# Altium schematic sheet PowerMotor.SchDoc(PowerMotor)
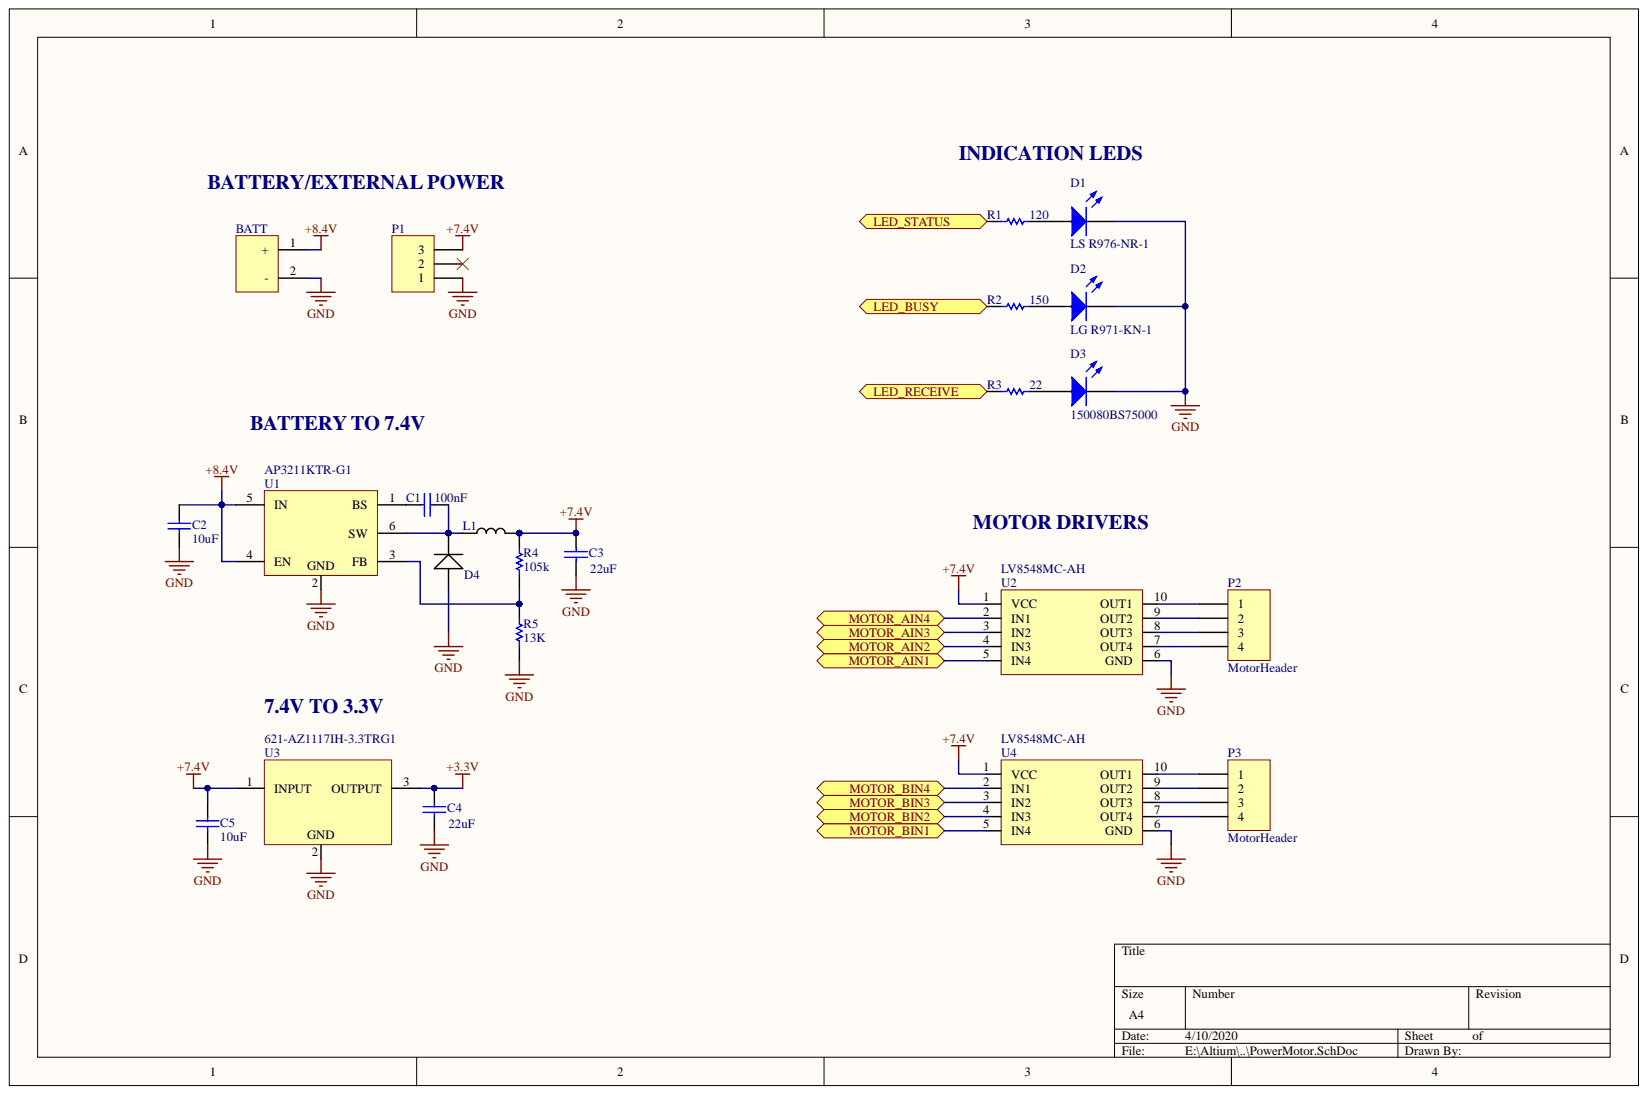
[diagram p0: PowerMotor.SchDoc]

## Parts
BATT  Holes  [BATTMOUNT]  (value not drawn)
C1  100nF  [C1206]
C2  10uF  [C1206]
C3  22uF  [C1206]
C4  22uF  [C1206]
C5  10uF  [C1206]
D1  LS R976-NR-1  [SMD LED 0805]
D2  LG R971-KN-1  [SMD LED 0805]
D3  150080BS75000  [SMD LED 0805]
D4  RSX205LAM30TR  [RSX205LAM30TR]  (value not drawn)
L1  744325550  [744325550]  (value not drawn)
P1  Header 3  [HDR1X3]  (value not drawn)
P2  MotorHeader  [HDR1X4]
P3  MotorHeader  [HDR1X4]
R1  120  [6-0805_N]
R2  150  [6-0805_N]
R3  22  [6-0805_N]
R4  105k  [6-0805_N]
R5  13K  [6-0805_N]
U1  AP3211KTR-G1  [AP3211KTR-G1]
U2  LV8548MC-AH  [LV8548MC-AH]
U3  AZ1117IH-3.3TRG1  Supplier Part Number 1: 621-AZ1117IH-3.3TRG1  [AZ1117IH-5.0TRG1]
U4  LV8548MC-AH  [LV8548MC-AH]

## Ports
LED_BUSY, LED_RECEIVE, LED_STATUS, MOTOR_AIN1, MOTOR_AIN2, MOTOR_AIN3, MOTOR_AIN4, MOTOR_BIN1, MOTOR_BIN2, MOTOR_BIN3, MOTOR_BIN4

## Nets
+3.3V: C4.2 U3.3
+7.4V: C3.2 C5.1 L1.2 P1.3 R4.2 U2.1(VCC) U3.1 U4.1(VCC)
+8.4V: BATT.1 C2.1 U1.4(EN) U1.5(IN)
GND: BATT.2 C2.2 C3.1 C4.1 C5.2 D1.2 D2.2 D3.2 D4.2 P1.1 R5.1 U1.2 U2.6(GND) U3.2 U4.6(GND)
LED_BUSY: R2.2 ; port LED_BUSY
LED_RECEIVE: R3.2 ; port LED_RECEIVE
LED_STATUS: R1.2 ; port LED_STATUS
MOTOR_AIN1: U2.5(IN4) ; port MOTOR_AIN1
MOTOR_AIN2: U2.4(IN3) ; port MOTOR_AIN2
MOTOR_AIN3: U2.3(IN2) ; port MOTOR_AIN3
MOTOR_AIN4: U2.2(IN1) ; port MOTOR_AIN4
MOTOR_BIN1: U4.5(IN4) ; port MOTOR_BIN1
MOTOR_BIN2: U4.4(IN3) ; port MOTOR_BIN2
MOTOR_BIN3: U4.3(IN2) ; port MOTOR_BIN3
MOTOR_BIN4: U4.2(IN1) ; port MOTOR_BIN4
NetC1_1: C1.1 D4.1 L1.1 U1.6(SW)
NetC1_2: C1.2 U1.1(BS)
NetD1_1: D1.1 R1.1
NetD2_1: D2.1 R2.1
NetD3_1: D3.1 R3.1
NetP2_1: P2.1 U2.10(OUT1)
NetP2_2: P2.2 U2.9(OUT2)
NetP2_3: P2.3 U2.8(OUT3)
NetP2_4: P2.4 U2.7(OUT4)
NetP3_1: P3.1 U4.10(OUT1)
NetP3_2: P3.2 U4.9(OUT2)
NetP3_3: P3.3 U4.8(OUT3)
NetP3_4: P3.4 U4.7(OUT4)
NetR4_1: R4.1 R5.2 U1.3(FB)

Not connected: P1 2

## Library data of the parts
BATT = Battery Terminal: Component Kind: Standard; Library Name: FSBPowerMotor.SchLib
C1-C5 = Cap Semi: Capacitor (Semiconductor SIM Model); Supplier 1: Mouser; Simulation: CAP; Signal Integrity: Cap Semi; Published: 8-Jun-2000; PackageReference: C1206; PackageDescription: Chip Capacitor; Library Name: Miscellaneous Devices.IntLib; LatestRevisionNote: IPC-7351 Footprint Added.; LatestRevisionDate: 29-May-2009; Component Kind: Standard; Publisher: Altium Limited
  C1: Value: 100nF; Supplier Part Number 1: 81-GRM31C5C1E104JA01
  C2 C5: Value: 10uF; Supplier Part Number 1: 963-GMK316BJ106KL-T
  C3 C4: Value: 22uF; Supplier Part Number 1: 963-TMK316BBJ226ML-T
D1 = LED2: LED RED DIFFUSED 0805 SMD; Simulation: LED2; Published: 8-Jun-2000; PackageVersion: Feb-2002; PackageReference: 3.2X1.6X1.1; PackageDescription: SM LED; 2 Flat Leads; Library Name: Miscellaneous Devices.IntLib; LatestRevisionNote: Re-released for DXP Platform.; LatestRevisionDate: 17-Jul-2002; Component Kind: Standard; Publisher: Altium Limited
D2 = LED2: LED GREEN DIFFUSED 0805 SMD; Simulation: LED2; Published: 8-Jun-2000; PackageVersion: Feb-2002; PackageReference: 3.2X1.6X1.1; PackageDescription: SM LED; 2 Flat Leads; Library Name: Miscellaneous Devices.IntLib; LatestRevisionNote: Re-released for DXP Platform.; LatestRevisionDate: 17-Jul-2002; Component Kind: Standard; Publisher: Altium Limited
D3 = LED2: LED BLUE CLEAR 0805 SMD; Simulation: LED2; Published: 8-Jun-2000; PackageVersion: Feb-2002; PackageReference: 3.2X1.6X1.1; PackageDescription: SM LED; 2 Flat Leads; Library Name: Miscellaneous Devices.IntLib; LatestRevisionNote: Re-released for DXP Platform.; LatestRevisionDate: 17-Jul-2002; Component Kind: Standard; Publisher: Altium Limited
D4 = RSX205LAM30TR: DIODE SCHOTTKY 30V 2A PMDTM; Manufacturer: Rohm; Supplier Part Number 1: 755-RSX205LAM30TR; Supplier 1: Mouser; Stock 1: 4282; RoHS: No; Pricing 1: 1=0.36000, 10=0.22800, 100=0.09800, 1000=0.07500, 3000=0.05700, 9000=0.05100 (USD); Packaging: Tape and Reel; Mount: Surface Mount; Max Reverse Voltage (DC): 30V; Manufacturer Part Number: RSX205LAM30TR; ComponentLink2URL: http://rohmfs.rohm.com/en/techdata_basic/diode/taping/Taping_PMDTM_TR-e.pdf?src-supplier=Digi-Key; ComponentLink2Description: http://rohmfs.rohm.com/en/techdata_basic/diode/taping/Taping_PMDTM_TR-e.pdf?src-supplier=Digi-Key; ComponentLink1URL: https://www.rohm.com/datasheet/RSX205LAM30/rsx205lam30tr-e?src-supplier=Digi-Key; ComponentLink1Description: https://www.rohm.com/datasheet/RSX205LAM30/rsx205lam30tr-e?src-supplier=Digi-Key; Component Kind: Standard; Average Rectified Current: 2A; Library Name: FSBPowerMotor.SchLib
L1 = 744325550: 5.5µH Shielded Wirewound Inductor 10A 10.3mOhm Nonstandard; Manufacturer Part Number: NR10050T6R5N; Manufacturer: Taiyo Yuden; Tolerance: 30%; Test Frequency: 100kHz; Self Resonant Frequency: 19MHz; Saturation Current: 6A; Inductance: 6.5uH; DC Resistance (DCR): 23.4mR; Current Rating: 5.2A; Core Material: Ferrite; Width: 0.386inch; Termination: SMD/SMT; Supplier Part Number 1: 710-744325550; Supplier 1: Mouser; Shielding: Shielded; RoHS: Yes; Radiation Hardening: No; Packaging: Tape and Reel; Package Quantity: 500; Number of Pins: 2; Mount: Surface Mount; Min Operating Temperature: -25°C; Max Operating Temperature: 105°C; Max DC Current: 6A; Length: 0.394inch; Lead Free: Lead Free; Height - Seated (Max): 0.197inch; Height: 5mm; Halogen Free: Halogen Free; Depth: 10.3mm; Composition: Wirewound; ComponentLink3URL: https://datasheet.ciiva.com/26793/nr10050t6r5n-26793515.pdf?src-supplier=IIIC; ComponentLink3Description: https://datasheet.ciiva.com/26793/nr10050t6r5n-26793515.pdf?src-supplier=IIIC; ComponentLink2URL: https://datasheet.ciiva.com/8504/nr10050t6r5n-ss-8504741.pdf?src-supplier=Avnet; ComponentLink2Description: https://datasheet.ciiva.com/8504/nr10050t6r5n-ss-8504741.pdf?src-supplier=Avnet; ComponentLink1URL: https://datasheet.ciiva.com/1046/nr10050t6r5n-ss-1046384.pdf?src-supplier=Digi-Key; ComponentLink1Description: https://datasheet.ciiva.com/1046/nr10050t6r5n-ss-1046384.pdf?src-supplier=Digi-Key; Component Kind: Standard; Case/Package: Nonstandard; Library Name: FSBPowerMotor.SchLib
P1 = Header 3: Header, 3-Pin; Signal Integrity: Connector; Library Name: Miscellaneous Connectors.IntLib; LatestRevisionNote: Re-released for DXP Platform.; LatestRevisionDate: 17-Jul-2002; Component Kind: Standard; Publisher: Altium Limited
P2 P3 = Header 4: Header, 4-Pin; Signal Integrity: Connector; Library Name: Miscellaneous Connectors.IntLib; LatestRevisionNote: Re-released for DXP Platform.; LatestRevisionDate: 17-Jul-2002; Component Kind: Standard; Publisher: Altium Limited
R1 R2 = Res Semi: Semiconductor Resistor; Value: 22; Simulation: RES; Signal Integrity: Res Semi; Published: 8-Jun-2000; PackageReference: AXIAL-0.5; PackageDescription: Axial Device, Thru-Hole; 2 Leads; 0.5 in Pin Spacing; Library Name: Miscellaneous Devices.IntLib; LatestRevisionNote: Re-released for DXP Platform.; LatestRevisionDate: 17-Jul-2002; Component Kind: Standard; Publisher: Altium Limited
R3-R5 = Res Semi: Semiconductor Resistor; Simulation: RES; Signal Integrity: Res Semi; Published: 8-Jun-2000; PackageReference: AXIAL-0.5; PackageDescription: Axial Device, Thru-Hole; 2 Leads; 0.5 in Pin Spacing; Library Name: Miscellaneous Devices.IntLib; LatestRevisionNote: Re-released for DXP Platform.; LatestRevisionDate: 17-Jul-2002; Component Kind: Standard; Publisher: Altium Limited
  R3: Value: 22
  R4: Value: 105k; Supplier Part Number 1: 667-ERJ-6ENF1053V; Supplier 1: Mouser
  R5: Value: 13K
U1 = AP3211KTR-G1: IC REG BUCK ADJ 1.5A SOT23-6; Manufacturer: Diodes; Synchronous Rectifier: No; Switching Frequency: 1.4MHz; Output Voltage: 15V; Output Type: Adjustable; Output Configuration: Positive; Operating Supply Voltage: 18V; Operating Supply Current: 800uA; Number of Outputs: 1; Min Supply Voltage: 4.5V; Min Output Voltage: 810mV; Efficiency: 92%; Width: 1.7mm; Weight: 0.000529oz; Supplier Part Number 1: 621-AP3211KTR-G1; Supplier 1: Mouser; Stock 1: 28910; RoHS: Yes; Pricing 1: 1=0.53000, 10=0.43400, 100=0.26500, 1000=0.20500, 3000=0.17400, 9000=0.17400 (USD); Packaging: Tape and Reel; Package Quantity: 3000; Output Current: 1.5A; Number of Pins: 6; Mount: Surface Mount; Min Operating Temperature: -40°C; Min Input Voltage: 4.5V; Max Output Voltage: 15V; Max Output Current: 1.5A; Max Operating Temperature: 85°C; Max Input Voltage: 18V; Manufacturer Part Number: AP3211KTR-G1; Length: 3.1mm; Lead Free: Lead Free; Height: 1.3mm; ComponentLink3URL: https://datasheet.ciiva.com/29737/ap3211-29737016.pdf?src-supplier=Digi-Key; ComponentLink3Description: https://datasheet.ciiva.com/29737/ap3211-29737016.pdf?src-supplier=Digi-Key; ComponentLink2URL: https://datasheet.ciiva.com/29885/ap3211-29885798.pdf?src-supplier=Avnet; ComponentLink2Description: https://datasheet.ciiva.com/29885/ap3211-29885798.pdf?src-supplier=Avnet; ComponentLink1URL: https://datasheet.ciiva.com/29737/ap3211-29737011.pdf?src-supplier=Digi-Key; ComponentLink1Description: https://datasheet.ciiva.com/29737/ap3211-29737011.pdf?src-supplier=Digi-Key; Component Kind: Standard; Case/Package: SOT-23-6; Library Name: FSBPowerMotor.SchLib
U2 U4 = LV8548MC-AH: IC MOTOR DRIVER PAR MFP10S - Half Bridge (4) Driver DC Motors, General Purpose, Stepper Motors DMOS 10-SOIC; Manufacturer: ON Semiconductor; Power Dissipation: 1W; Output Current: 1A; Number of Outputs: 2; Nominal Supply Current: 65uA; Min Supply Voltage: 4V; Max Supply Voltage: 16V; Width: 3.9mm; Supplier Part Number 1: 863-LV8548MC-AH; Supplier 1: Mouser; Stock 1: 6214; RoHS: Yes; REACH SVHC: No SVHC; Radiation Hardening: No; Pricing 1: 1=1.28000, 10=1.10000, 100=0.83900, 500=0.74200, 1000=0.58500, 2500=0.51900, 10000=0.50000, 25000=0.48400, 50000=0.46900 (USD); Packaging: Tape and Reel; Package Quantity: 1; Number of Pins: 10; Mount: Surface Mount; Motor Type: Stepper; Min Operating Temperature: -30°C; Max Output Current: 1A; Max Operating Temperature: 85°C; Max Collector Current: 1A; Manufacturer Part Number: LV8548MC-AH; Length: 4.9mm; Lead Free: Lead Free; Height: 1.5mm; Halogen Free: Halogen Free; ComponentLink5URL: https://datasheet.ciiva.com/8199/ena2038-d-8199627.pdf?src-supplier=Avnet; ComponentLink5Description: https://datasheet.ciiva.com/8199/ena2038-d-8199627.pdf?src-supplier=Avnet; ComponentLink4URL: https://datasheet.ciiva.com/23656/1758700-23656330.pdf?src-supplier=Newark; ComponentLink4Description: https://datasheet.ciiva.com/23656/1758700-23656330.pdf?src-supplier=Newark; ComponentLink3URL: https://datasheet.ciiva.com/26085/ena2038-d-26085732.pdf?src-supplier=Avnet+Europe; ComponentLink3Description: https://datasheet.ciiva.com/26085/ena2038-d-26085732.pdf?src-supplier=Avnet+Europe; ComponentLink2URL: https://datasheet.ciiva.com/15109/getdatasheetpartid-653946-15109696.pdf?src-supplier=Verical; ComponentLink2Description: https://datasheet.ciiva.com/15109/getdatasheetpartid-653946-15109696.pdf?src-supplier=Verical; ComponentLink1URL: https://datasheet.ciiva.com/1959/ena2038-d-1959280.pdf?src-supplier=Digi-Key; ComponentLink1Description: https://datasheet.ciiva.com/1959/ena2038-d-1959280.pdf?src-supplier=Digi-Key; Component Kind: Standard; Collector Emitter Voltage (VCEO): 16V; Case/Package: SOIC; Library Name: FSBPowerMotor.SchLib
U3 = AZ1117IH-5.0TRG1: IC REG LINEAR 5V 800MA SOT223; Manufacturer: Diodes; Number of Regulators: 1; Min Current Limit: 1A; Dropout Voltage: 1.2V; Supplier 1: Mouser; Stock 1: 3552; RoHS: Yes; Pricing 1: 1=0.36000, 10=0.27200, 100=0.14800, 1000=0.11100, 4000=0.09600, 8000=0.08900 (USD); Packaging: Tape and Reel; Output Voltage: 5V; Output Current: 800mA; Mount: Surface Mount; Min Operating Temperature: -40°C; Max Operating Temperature: 125°C; Manufacturer Part Number: AZ1117IH-5.0TRG1; ComponentLink1URL: https://www.diodes.com/assets/Datasheets/AZ1117I.pdf?src-supplier=Digi-Key; ComponentLink1Description: https://www.diodes.com/assets/Datasheets/AZ1117I.pdf?src-supplier=Digi-Key; Component Kind: Standard; Case/Package: TO-261-4; Library Name: FSBPowerMotor.SchLib
